# Altium schematic sheet VU_LED.SchDoc
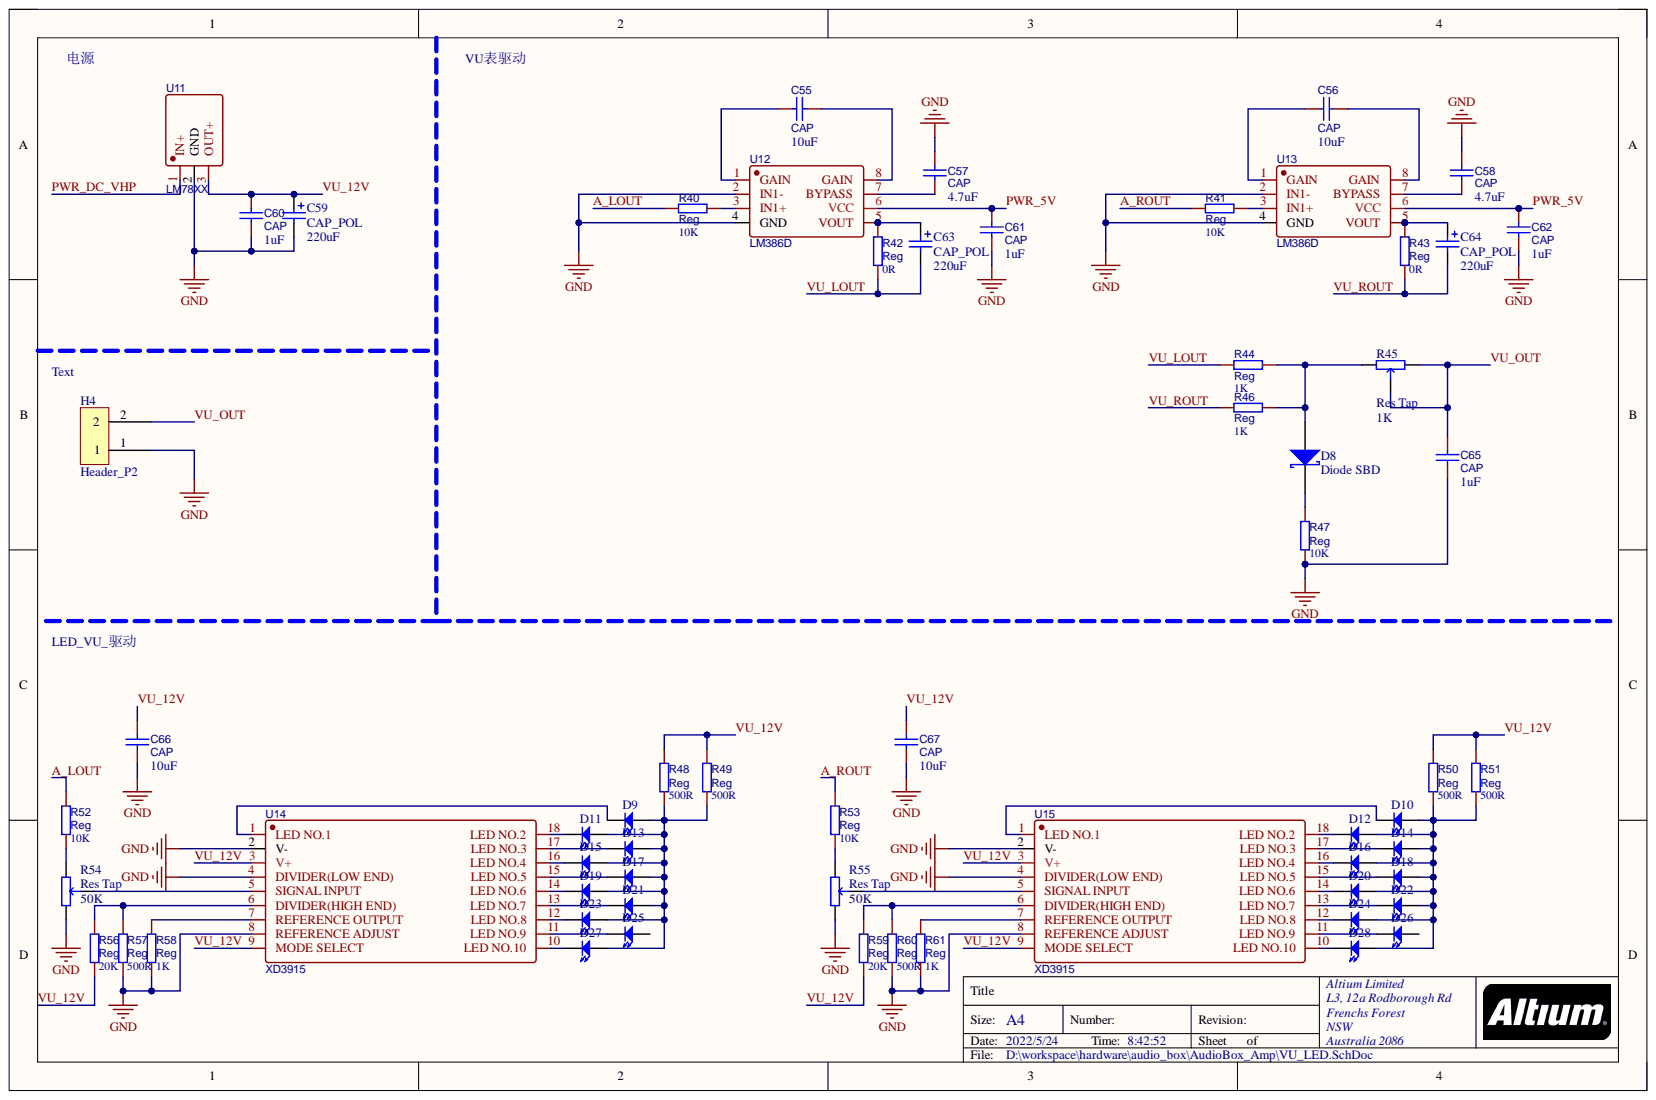
[diagram p0: VU_LED.SchDoc]

## Parts
C55  CAP  value 10uF  [C0603]
C56  CAP  value 10uF  [C0603]
C57  CAP  value 4.7uF  [C0603]
C58  CAP  value 4.7uF  [C0603]
C59  CAP_POL  value 220uF  [CAP_SMD_6.3mm]
C60  CAP  value 1uF  [C0603]
C61  CAP  value 1uF  [C0603]
C62  CAP  value 1uF  [C0603]
C63  CAP_POL  value 220uF  [CAP_SMD_6.3mm]
C64  CAP_POL  value 220uF  [CAP_SMD_6.3mm]
C65  CAP  value 1uF  [C0603]
C66  CAP  value 10uF  [C0603]
C67  CAP  value 10uF  [C0603]
D8  Diode SBD  [SMA_L4.3]
D9  LED  [LED0603]  (value not drawn)
D10  LED  [LED0603]  (value not drawn)
D11  LED  [LED0603]  (value not drawn)
D12  LED  [LED0603]  (value not drawn)
D13  LED  [LED0603]  (value not drawn)
D14  LED  [LED0603]  (value not drawn)
D15  LED  [LED0603]  (value not drawn)
D16  LED  [LED0603]  (value not drawn)
D17  LED  [LED0603]  (value not drawn)
D18  LED  [LED0603]  (value not drawn)
D19  LED  [LED0603]  (value not drawn)
D20  LED  [LED0603]  (value not drawn)
D21  LED  [LED0603]  (value not drawn)
D22  LED  [LED0603]  (value not drawn)
D23  LED  [LED0603]  (value not drawn)
D24  LED  [LED0603]  (value not drawn)
D25  LED  [LED0603]  (value not drawn)
D26  LED  [LED0603]  (value not drawn)
D27  LED  [LED0603]  (value not drawn)
D28  Publisher: Altium Limited  [LED0603]  (hidden comment: LED)
H4  Header_P2  [H_2.54_P4]
R40  Reg  value 10K  [R0603]
R41  Reg  value 10K  [R0603]
R42  Reg  value 0R  [R0603]
R43  Reg  value 0R  [R0603]
R44  Reg  value 1K  [R0603]
R45  Res Tap  value 1K  [RES_TAP_HOR]
R46  Reg  value 1K  [R0603]
R47  Reg  value 10K  [R0603]
R48  Reg  value 500R  [R0603]
R49  Reg  value 500R  [R0603]
R50  Reg  value 500R  [R0603]
R51  Reg  value 500R  [R0603]
R52  Reg  value 10K  [R0603]
R53  Reg  value 10K  [R0603]
R54  Res Tap  value 50K  [RES_TAP_HOR]
R55  Res Tap  value 50K  [RES_TAP_HOR]
R56  Reg  value 20K  [R0603]
R57  Reg  value 500R  [R0603]
R58  Reg  value 1K  [R0603]
R59  Reg  value 20K  [R0603]
R60  Reg  value 500R  [R0603]
R61  Reg  value 1K  [R0603]
U11  LM78XX  [TO-220-3_2.54_HOR]
U12  LM386D  [SOP-8]
U13  LM386D  [SOP-8]
U14  XD3915  [DIP-18]
U15  XD3915  [DIP-18]

## Nets
GND: C57.1 C58.1 C59.2 C60.2 C61.2 C62.2 C65.2 C66.2 C67.2 H4.1 R47.2 R54.2 R55.2 R57.2 R58.2 R60.2 R61.2 U11.2 U12.2(IN1-) U12.4(GND) U13.2(IN1-) U13.4(GND) U14.2(V-) U14.4(DIVIDER(LOW_END)) U14.8(REFERENCE_ADJUST) U15.2(V-) U15.4(DIVIDER(LOW_END)) U15.8(REFERENCE_ADJUST)
A_LOUT: R40.2 R52.2
A_ROUT: R41.2 R53.2
PWR_5V: C61.1 C62.1 U12.6(VCC) U13.6(VCC)
PWR_DC_VHP: U11.1
VU_12V: C59.1 C60.1 C66.1 C67.1 R48.2 R49.2 R50.2 R51.2 R56.2 R59.2 U11.3 U14.3(V+) U14.9(MODE_SELECT) U15.3(V+) U15.9(MODE_SELECT)
VU_LOUT: C63.2 R42.2 R44.2
VU_OUT: C65.1 H4.2 R45.1 R45.3
VU_ROUT: C64.2 R43.2 R46.2
NetC55_1: C55.1 U12.1(GAIN)
NetC55_2: C55.2 U12.8(GAIN)
NetC56_1: C56.1 U13.1(GAIN)
NetC56_2: C56.2 U13.8(GAIN)
NetC57_2: C57.2 U12.7(BYPASS)
NetC58_2: C58.2 U13.7(BYPASS)
NetC63_1: C63.1 R42.1 U12.5(VOUT)
NetC64_1: C64.1 R43.1 U13.5(VOUT)
NetD8_1: D8.1 R47.1
NetD8_2: D8.2 R44.1 R45.2 R46.1
NetD9_1: D9.1 U14.1(LED_NO.1)
NetD9_2: D9.2 D11.2 D13.2 D15.2 D17.2 D19.2 D21.2 D23.2 D27.2 R48.1 R49.1
NetD10_1: D10.1 U15.1(LED_NO.1)
NetD10_2: D10.2 D12.2 D14.2 D16.2 D18.2 D20.2 D22.2 D24.2 D28.2 R50.1 R51.1
NetD11_1: D11.1 U14.18(LED_NO.2)
NetD12_1: D12.1 U15.18(LED_NO.2)
NetD13_1: D13.1 U14.17(LED_NO.3)
NetD14_1: D14.1 U15.17(LED_NO.3)
NetD15_1: D15.1 U14.16(LED_NO.4)
NetD16_1: D16.1 U15.16(LED_NO.4)
NetD17_1: D17.1 U14.15(LED_NO.5)
NetD18_1: D18.1 U15.15(LED_NO.5)
NetD19_1: D19.1 U14.14(LED_NO.6)
NetD20_1: D20.1 U15.14(LED_NO.6)
NetD21_1: D21.1 U14.13(LED_NO.7)
NetD22_1: D22.1 U15.13(LED_NO.7)
NetD23_1: D23.1 U14.12(LED_NO.8)
NetD24_1: D24.1 U15.12(LED_NO.8)
NetD25_1: D25.1 U14.11(LED_NO.9)
NetD26_1: D26.1 U15.11(LED_NO.9)
NetD27_1: D27.1 U14.10(LED_NO.10)
NetD28_1: D28.1 U15.10(LED_NO.10)
NetR40_1: R40.1 U12.3(IN1+)
NetR41_1: R41.1 U13.3(IN1+)
NetR52_1: R52.1 R54.1
NetR53_1: R53.1 R55.1
NetR54_3: R54.3 U14.5(SIGNAL_INPUT)
NetR55_3: R55.3 U15.5(SIGNAL_INPUT)
NetR56_1: R56.1 R57.1 U14.6(DIVIDER(HIGH_END))
NetR58_1: R58.1 U14.7(REFERENCE_OUTPUT)
NetR59_1: R59.1 R60.1 U15.6(DIVIDER(HIGH_END))
NetR61_1: R61.1 U15.7(REFERENCE_OUTPUT)

Not connected: D25 2; D26 2

## Library data of the parts
C55-C58 C60-C62 C65-C67 = CAP: BOM_Manufacturer: Huaxin S&T; BOM_Manufacturer Part: 0603B103K101CT; Contributor: LCSC; nameAlias: Capacitance; BOM_Supplier: LCSC; BOM_Supplier Part: C302008; Component Kind: Standard; Description: Cap; spicePre: C; spiceSymbolName: 0603B103K101CT; Library Name: Levent_lib.IntLib
C59 C63 C64 = CAP_POL: 极性电容; Component Kind: Standard; Library Name: Levent_lib.IntLib
D8 = Diode SBD: Schottky Rectifier; Note: Terminal 2 is the TAB and is electrically connected to the cathode; Component Kind: Standard; ComponentLink1Description: Manufacturer Link; ComponentLink1URL: http://www.irf.com/; ComponentLink2Description: Datasheet; ComponentLink2URL: http://www.irf.com/product-info/datasheets/data/10tq.pdf; DatasheetVersion: PD-2.057B; LatestRevisionDate: 17-Jul-2002; LatestRevisionNote: Re-released for DXP Platform.; PackageDescription: TO, Thru-Hole, Vertical, Heatsink Mounted; 3 In-Line Leads (1 Absent); Pitch 2.54 mm; PackageReference: TO-220AC; PackageVersion: Feb-1998; Published: 8-Jun-2000; Simulation: 10TQ035; Library Name: Levent_lib.IntLib; Publisher: Altium Limited
D9-D28 = LED0: Component Kind: Standard; Description: LED; LatestRevisionDate: 08-Jul-2005; LatestRevisionNote: Mech Layer 1 removed.; PackageDescription: LED; 2 Leads; PackageReference: LED-0; Published: 8-Jun-2000; Simulation: LED0; Library Name: Levent_lib.IntLib
  D9-D27: Publisher: Altium Limited
H4 = Header_P2: 2Pin排针; Component Kind: Standard; Library Name: Levent_lib.IntLib
R40-R43 R46-R53 R56-R61 = Res: 电阻; BOM_Manufacturer: Viking Tech; BOM_Manufacturer Part: AR03BTCX1002A; Contributor: LCSC; nameAlias: Resistance (Ohms); BOM_Supplier: LCSC; BOM_Supplier Part: C465721; Component Kind: Standard; spicePre: R; spiceSymbolName: AR03BTCX1002A; Library Name: Levent_lib.IntLib
R44 = Res: 电阻; BOM_Manufacturer: Viking Tech; BOM_Manufacturer Part: AR03BTCX1002A; Contributor: LCSC; nameAlias: Resistance (Ohms); Value: 1K; BOM_Supplier: LCSC; BOM_Supplier Part: C465721; Component Kind: Standard; spicePre: R; spiceSymbolName: AR03BTCX1002A; Library Name: Levent_lib.IntLib
R45 R54 R55 = Res Tap: 可调电阻; Set Position: 0.5; Sim Note: Enter the Resistance value and the set point into parameter fields.; Component Kind: Standard; LatestRevisionDate: 17-Jul-2002; LatestRevisionNote: Re-released for DXP Platform.; PackageDescription: Variable Resistor, Thru-Hole; 3 Leads; PackageReference: VR3; Published: 24-Mar-1999; Simulation: POT; Library Name: Levent_lib.IntLib; Publisher: Altium Limited
U11 = LM78XX: 三端稳压(正电压); BOM_Manufacturer: HGSEMI(华冠); BOM_Manufacturer Part: LM7812T; Contributor: LCSC; BOM_JLCPCB Part Class: Extended Part; BOM_Supplier: LCSC; BOM_Supplier Part: C2902509; Component Kind: Standard; spicePre: U; spiceSymbolName: LM7812T; Library Name: Levent_lib.IntLib
U12 U13 = LM386D: LM386; BOM_Manufacturer: HTC Korea TAEJIN Tech; Contributor: LCSC; BOM_JLCPCB Part Class: Extended Part; BOM_Manufacturer Part: LM386D; BOM_Supplier: LCSC; BOM_Supplier Part: C510560; Component Kind: Standard; spicePre: U; spiceSymbolName: LM386D; Library Name: Levent_lib.IntLib
U14 U15 = LM3915: 电平指示; BOM_Manufacturer: XINLUDA(信路达); Contributor: LCSC; BOM_JLCPCB Part Class: Extended Part; BOM_Manufacturer Part: XD3915; BOM_Supplier: LCSC; BOM_Supplier Part: C609778; Component Kind: Standard; Frequency: -; spicePre: U; spiceSymbolName: XD3915; Library Name: Levent_lib.IntLib
